# Altium schematic sheet Sheet1.SchDoc(Sheet1)
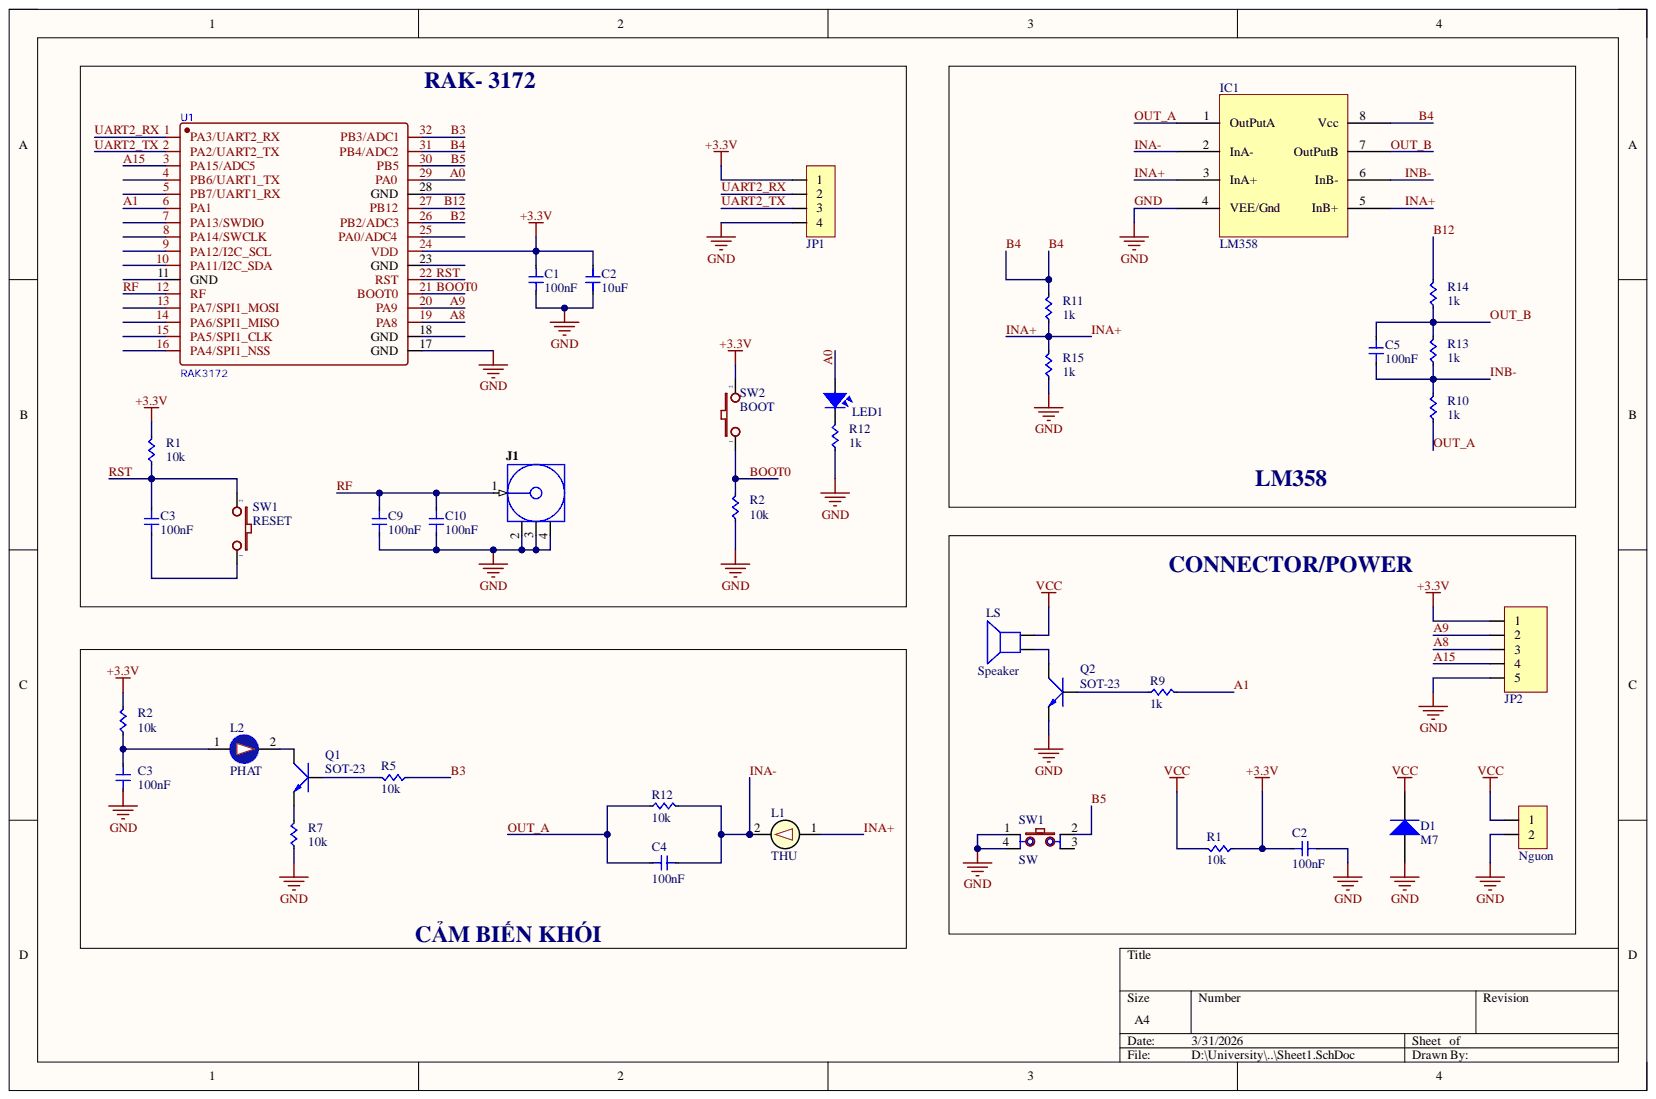
[diagram p0: Sheet1.SchDoc]

## Parts
C1  100nF  [Cap 0603]
C2  100nF  [Cap 0603]
C2  10uF  [Cap 0603]
C3  100nF  [Cap 0603]
C3  100nF  [Cap 0603]
C4  100nF  [Cap 0603]
C5  100nF  [Cap 0603]
C9  100nF  [Cap 0603]
C10  100nF  [Cap 0603]
D1  M7  [DIODE SMA]
IC1  LM358  [SOP 8]
J1  (no value)  [CON RF]
JP1  JP1  [JP 1x4 DT]
JP2  JP2  [JP 1x5 DT]
L1  THU  [LED-5MM-WHITE]
L2  PHAT  [LED-5MM-BLUE]
LED1  LED  [LED-0805-Green]
LS  Speaker  [Coi Chip Speacker]
Nguon  Nguon  [JP 1x2 DT]
Q1  SOT-23  [SOT-23]
Q2  SOT-23  [SOT-23]
R1  10k  [RES 0603]
R1  10k  [RES 0603]
R2  10k  [RES 0603]
R2  10k  [RES 0603]
R5  10k  [RES 0603]
R7  10k  [RES 0603]
R9  1k  [RES 0603]
R10  1k  [RES 0603]
R11  1k  [RES 0603]
R12  10k  [RES 0603]
R12  1k  [RES 0603]
R13  1k  [RES 0603]
R14  1k  [RES 0603]
R15  1k  [RES 0603]
SW1  SW  [SW2-DIP]
SW1  RESET  [SW1-SMD]
SW2  BOOT  [SW1-SMD]
U1  RAK3172  [LCC-40_L15.6-W15.0-P1.00_RAK3172]

## Nets
+3.3V: C1.2 C2.1 JP1.1 JP2.1 R1.1 R1.2 R2.2 SW2.2 U1.24(VDD)
VCC: D1.2 LS.2 Nguon.1 R1.2
A0: LED1.1 U1.29(PA0)
A1: R9.2 U1.6(PA1)
A8: JP2.3 U1.19(PA8)
A9: JP2.2 U1.20(PA9)
A15: JP2.4 U1.3(PA15/ADC5)
B2: U1.26(PB2/ADC3)
B3: R5.1 U1.32(PB3/ADC1)
B4: IC1.8(Vcc) R11.2 U1.31(PB4/ADC2)
B5: SW1.2 U1.30(PB5)
B12: R14.2 U1.27(PB12)
BOOT0: R2.2 SW2.1 U1.21(BOOT0)
GND: C1.1 C2.2 C3.1 C9.2 C10.1 D1.1 IC1.4(VEE/Gnd) J1.2 J1.3 J1.4 JP1.4 JP2.5 Nguon.2 Q2.2 R2.1 R7.1 R12.1 R15.1 SW1.1 SW1.4 U1.17(GND)
INA+: IC1.3(InA+) IC1.5(InB+) L1.1 R11.1 R15.2
INA-: C4.1 IC1.2(InA-) L1.2 R12.2
INB-: C5.1 IC1.6(InB-) R10.2 R13.1
OUT_A: C4.2 IC1.1(OutPutA) R10.1 R12.1
OUT_B: C5.2 IC1.7(OutPutB) R13.2 R14.1
RF: C9.1 C10.2 J1.1 U1.12(RF)
RST: C3.2 R1.1 SW1.2 U1.22(RST)
UART2_RX: JP1.2 U1.1(PA3/UART2_RX)
UART2_TX: JP1.3 U1.2(PA2/UART2_TX)
NetC3_1: C3.1 SW1.1
NetC3_2: C3.2 L2.1 R2.1
NetL2_2: L2.2 Q1.3
NetLED1_2: LED1.2 R12.2
NetLS_1: LS.1 Q2.3
NetQ1_1: Q1.1 R5.2
NetQ1_2: Q1.2 R7.2
NetQ2_1: Q2.1 R9.1

Not connected: SW1 3; U1 4(PB6/UART1_TX) 5(PB7/UART1_RX) 7(PA13/SWDIO) 8(PA14/SWCLK) 9(PA12/I2C_SCL) 10(PA11/I2C_SDA) 11(GND) 13(PA7/SPI1_MOSI) 14(PA6/SPI1_MISO) 15(PA5/SPI1_CLK) 16(PA4/SPI1_NSS) 18(GND) 23(GND) 25(PA0/ADC4) 28(GND)

## Library data of the parts
C1 C2 C2 C3 C3-C5 C9 C10 = Cap 0603: Capacitor SMD 0603; Component Kind: Standard; Library Name: Altium_Lib_by_KhueNguyenCreator.IntLib
D1 = Diode M7 ( 4007 ): Dán; Don_Vi: Con; Ma_Hang: MH000944; Ten_Linh_Kien: Diode M7 1N4007 SMD 1A 1000V; Chung_Loai: Dán; Component Kind: Standard; Library Name: Altium_Lib_by_KhueNguyenCreator.IntLib
IC1 = LM358 SOP8: Dán; Don_Vi: Con; Ma_Hang: MH000609; Chung_Loai: Dán; Component Kind: Standard; Ten_Linh_Kien: LM358 SOP8; Library Name: Altium_Lib_by_KhueNguyenCreator.IntLib
J1 = CON RF: Microcoaxial RF, 50 Ohm, PCB Vertical Jack Receptacle, SMT, 1.25mm Mounted Height; Body Length: 4 mm; Class: Connector; Sub-class: Serial Bus; Component Kind: Standard; Datasheet: http://www.molex.com/webdocs/datasheets/pdf/en-us/0734120110_RF_COAX_CONNECTORS.pdf; IEC-class: Connector; Library Name: Altium Library.IntLib; Terminal Pitch: 3 mm
JP1 = JP 1x4 2.54 Duc Thang: Cắm; Don_Vi: Con; Ma_Hang: MH000903; Ten_Linh_Kien: Jump Đơn Đực 2.54MM 1x40P Thẳng 11MM; Chung_Loai: Cắm; Component Kind: Standard; Library Name: Altium_Lib_by_KhueNguyenCreator.IntLib
JP2 = JP 1x5 2.54 Duc Thang: Cắm; Don_Vi: Con; Ma_Hang: MH000903; Ten_Linh_Kien: Jump Đơn Đực 2.54MM 1x40P Thẳng 11MM; Chung_Loai: Cắm; Component Kind: Standard; Library Name: Altium_Lib_by_KhueNguyenCreator.IntLib
L1 = LED 5mm Trang: Cắm; Don_Vi: Con; Ma_Hang: MH008983; Ten_Linh_Kien: Led 5MM Trắng Đục (1000c); Chung_Loai: Cắm; Component Kind: Standard; Library Name: Altium_Lib_by_KhueNguyenCreator.IntLib
L2 = LED 5mm Xanh Duong: Cắm; Don_Vi: Con; Ma_Hang: MH008987; Ten_Linh_Kien: Led 5MM Xanh Dương Đục (1000c); Chung_Loai: Cắm; Component Kind: Standard; Library Name: Altium_Lib_by_KhueNguyenCreator.IntLib
LED1 = LED SMD: Value: LED 3 - 5 ly 2 Colour; Component Kind: Standard; Library Name: Altium Library.IntLib
LS = Coi Chip Speaker: Còi chip; Component Kind: Standard; Library Name: Altium_Lib_by_KhueNguyenCreator.IntLib
Nguon = JP 1x2 2.54 Duc Thang: Cắm; Don_Vi: Con; Ma_Hang: MH000903; Ten_Linh_Kien: Jump Đơn Đực 2.54MM 1x40P Thẳng 11MM; Chung_Loai: Cắm; Component Kind: Standard; Library Name: Altium_Lib_by_KhueNguyenCreator.IntLib
Q1 Q2 = Trans C1815 HF SMD: Value: C9014; Component Kind: Standard; Description: SOT-23; Library Name: Altium_Lib_by_KhueNguyenCreator.IntLib
R1 R1 R2 R2 R5 R7 R12 = RES 0603: RES SMD 0603; Manufacturer: Vishay Dale; Manufacturer Part Number: CRCW040210K0FKED; Power (Watts): 0.063W, 1/16W; Temperature Coefficient: ±100ppm/°C; Tolerance: ±1%; Category: Resistors; Component Kind: Standard; ComponentLink1Description: http://www.vishay.com/docs/20035/dcrcwe3.pdf; ComponentLink1URL: http://www.vishay.com/docs/20035/dcrcwe3.pdf; Composition: Thick Film; Features: Automotive AEC-Q200; Height: 0.016" (0.40mm); Number of Terminations: 2; Operating Temperature: -55°C ~ 155°C; Package / Case: 0402 (1005 Metric); Packaging: Cut Tape (CT); Pricing 1: 10=0.083, 50=0.0444, 200=0.0255, 1000=0.0174 (USD); Resistance (Ohms): 10k; RoHS: RoHS Compliant; Size / Dimension: 0.039" L x 0.020" W (1.00mm x 0.50mm); Stock 1: 2392462; Supplier 1: Digi-Key; Supplier Device Package: 0402 (1005 Metric); Supplier Part Number 1: 541-10.0KLCT-ND; Library Name: Altium_Lib_by_KhueNguyenCreator.IntLib
R9-R15 = RES 0603: RES SMD 0603; Manufacturer: Vishay Dale; Manufacturer Part Number: CRCW040210K0FKED; Power (Watts): 0.063W, 1/16W; Resistance (Ohms): 10k; Temperature Coefficient: ±100ppm/°C; Tolerance: ±1%; Category: Resistors; Component Kind: Standard; ComponentLink1Description: http://www.vishay.com/docs/20035/dcrcwe3.pdf; ComponentLink1URL: http://www.vishay.com/docs/20035/dcrcwe3.pdf; Composition: Thick Film; Features: Automotive AEC-Q200; Height: 0.016" (0.40mm); Number of Terminations: 2; Operating Temperature: -55°C ~ 155°C; Package / Case: 0402 (1005 Metric); Packaging: Cut Tape (CT); Pricing 1: 10=0.083, 50=0.0444, 200=0.0255, 1000=0.0174 (USD); RoHS: RoHS Compliant; Size / Dimension: 0.039" L x 0.020" W (1.00mm x 0.50mm); Stock 1: 2392462; Supplier 1: Digi-Key; Supplier Device Package: 0402 (1005 Metric); Supplier Part Number 1: 541-10.0KLCT-ND; Library Name: Altium_Lib_by_KhueNguyenCreator.IntLib
SW1 = SW4: Switch button 4 pin; Value: Button chip 4 pin; Component Kind: Standard; Library Name: Altium Library.IntLib
SW1 SW2 = SW2: Switch button 2 pin; Value: SW chip 2 pin; Component Kind: Standard; Library Name: Altium Library.IntLib
U1 = RAK3172 (EU868, WITHOUT IPEX): Component Kind: Standard; Library Name: My_Library.IntLib
